# Altium schematic sheet USB3300 ULPI Board.SchDoc("USB3300 ULPI Board")
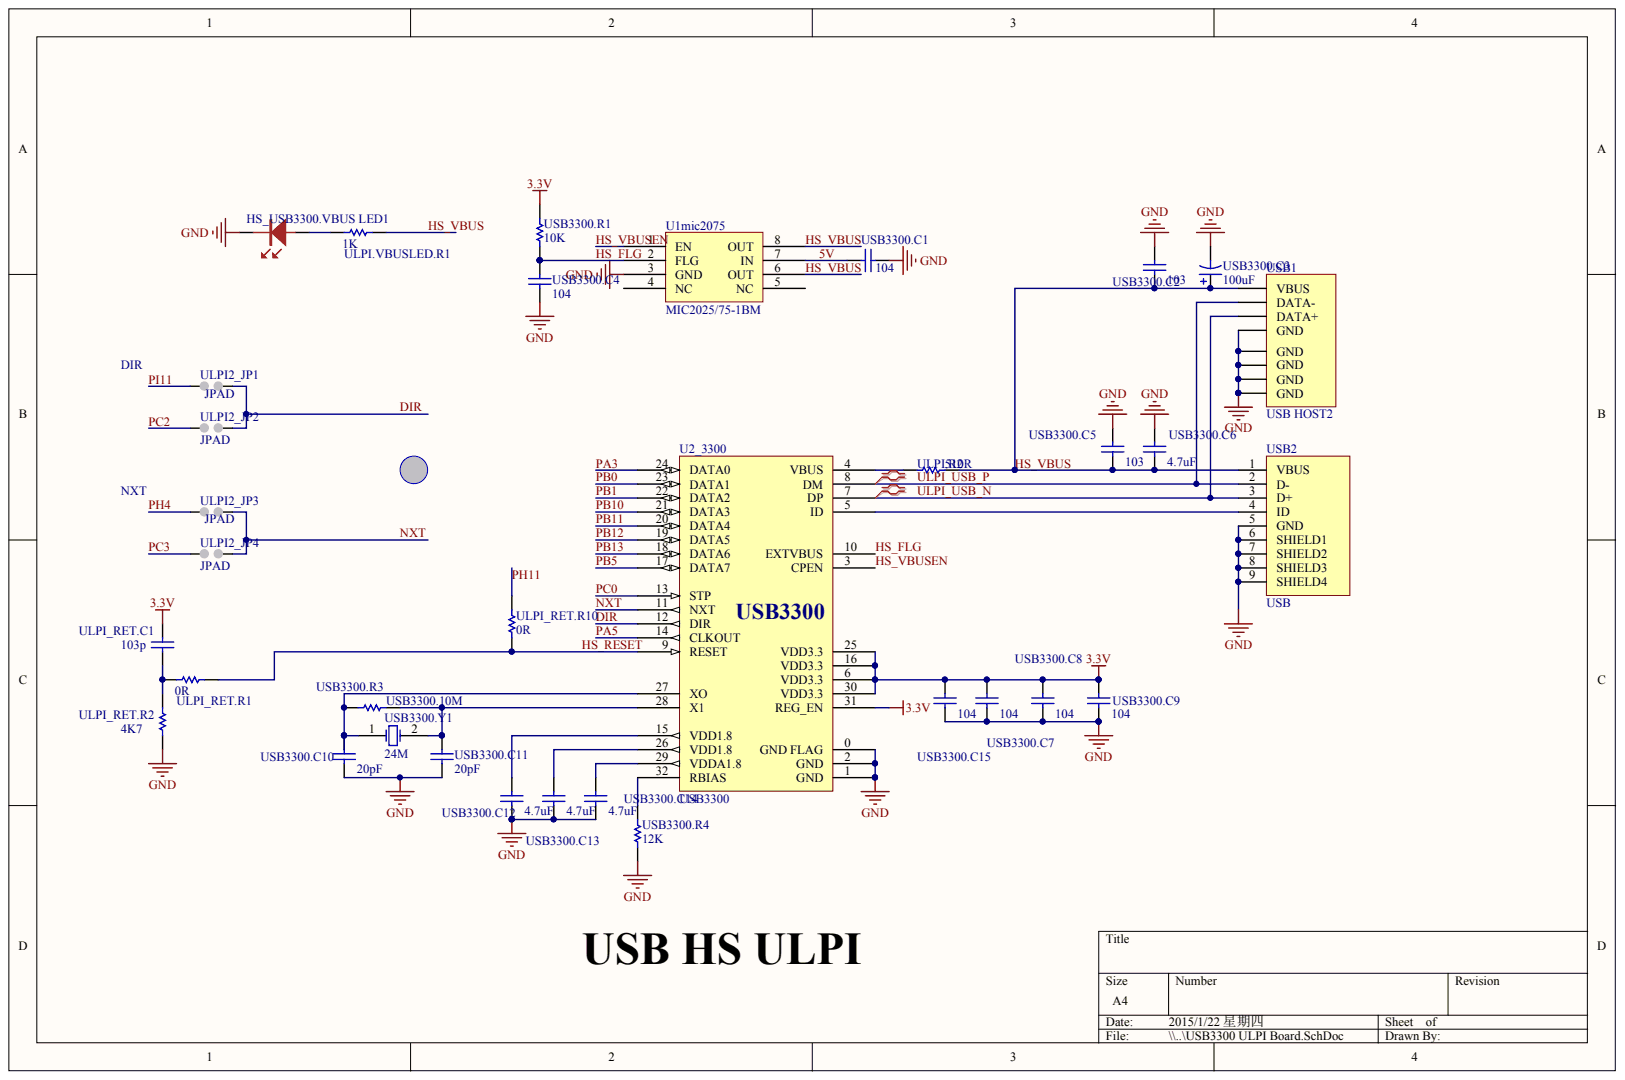
[diagram p0: USB3300 ULPI Board.SchDoc]

## Parts
HS_USB3300.VBUS_LED1  LED_0805  (value not drawn)
U1mic2075  MIC2025/75-1BM
U2_3300  USB3300
ULPI2_JP1  JPAD
ULPI2_JP2  JPAD
ULPI2_JP3  JPAD
ULPI2_JP4  JPAD
ULPI.R2  510R
ULPI.VBUSLED.R1  1K
ULPI_RET.C1  103p
ULPI_RET.R1  0R
ULPI_RET.R2  4K7
ULPI_RET.R10  0R
USB1  USB HOST2
USB2  USB
USB3300.C1  104
USB3300.C2  103
USB3300.C3  100uF
USB3300.C4  104
USB3300.C5  103
USB3300.C6  4.7uF
USB3300.C7  104
USB3300.C8  104
USB3300.C9  104
USB3300.C10  20pF
USB3300.C11  20pF
USB3300.C12  4.7uF
USB3300.C13  4.7uF
USB3300.C14  4.7uF
USB3300.C15  104
USB3300.R1  10K
USB3300.R3  USB3300.10M
USB3300.R4  12K
USB3300.Y1  24M

## Nets
3.3V: U2_3300.6(VDD3.3) U2_3300.16(VDD3.3) U2_3300.25(VDD3.3) U2_3300.30(VDD3.3) U2_3300.31(REG_EN) ULPI_RET.C1.2 USB3300.C7.1 USB3300.C8.1 USB3300.C9.1 USB3300.C15.1 USB3300.R1.1
GND: HS_USB3300.VBUS_LED1.2 U1mic2075.3(GND) U2_3300.0(GND_FLAG) U2_3300.1(GND) U2_3300.2(GND) ULPI_RET.R2.1 USB1.4(GND) USB1.5(GND) USB1.6(GND) USB1.7(GND) USB1.8(GND) USB2.5(GND) USB2.6(SHIELD1) USB2.7(SHIELD2) USB2.8(SHIELD3) USB2.9(SHIELD4) USB3300.C1.1 USB3300.C2.2 USB3300.C3.1 USB3300.C4.2 USB3300.C5.2 USB3300.C6.2 USB3300.C7.2 USB3300.C8.2 USB3300.C9.2 USB3300.C10.1 USB3300.C11.1 USB3300.C12.1 USB3300.C13.1 USB3300.C14.1 USB3300.C15.2 USB3300.R4.2
5V: U1mic2075.7(IN) USB3300.C1.2
DIR: U2_3300.12(DIR) ULPI2_JP1.1 ULPI2_JP2.1
HS_FLG: U1mic2075.2(FLG) U2_3300.10(EXTVBUS) USB3300.C4.1 USB3300.R1.2
HS_RESET: U2_3300.9(RESET) ULPI_RET.R1.1 ULPI_RET.R10.2
HS_VBUS: U1mic2075.6(OUT) U1mic2075.8(OUT) ULPI.R2.2 ULPI.VBUSLED.R1.1 USB1.1(VBUS) USB2.1(VBUS) USB3300.C2.1 USB3300.C3.2 USB3300.C5.1 USB3300.C6.1
HS_VBUSEN: U1mic2075.1(EN) U2_3300.3(CPEN)
NXT: U2_3300.11(NXT) ULPI2_JP3.1 ULPI2_JP4.1
PA3: U2_3300.24(DATA0)
PA5: U2_3300.14(CLKOUT)
PB0: U2_3300.23(DATA1)
PB1: U2_3300.22(DATA2)
PB5: U2_3300.17(DATA7)
PB10: U2_3300.21(DATA3)
PB11: U2_3300.20(DATA4)
PB12: U2_3300.19(DATA5)
PB13: U2_3300.18(DATA6)
PC0: U2_3300.13(STP)
PC2: ULPI2_JP2.2
PC3: ULPI2_JP4.2
PH4: ULPI2_JP3.2
PH11: ULPI_RET.R10.1
PI11: ULPI2_JP1.2
ULPI_USB_N: U2_3300.7(DP) USB1.3(DATA+) USB2.3(D+)
ULPI_USB_P: U2_3300.8(DM) USB1.2(DATA-) USB2.2(D-)
NetHS_USB3300.VBUS LED1_1: HS_USB3300.VBUS_LED1.1 ULPI.VBUSLED.R1.2
NetU2_3300_4: U2_3300.4(VBUS) ULPI.R2.1
NetU2_3300_5: U2_3300.5(ID) USB2.4(ID)
NetU2_3300_15: U2_3300.15(VDD1.8) USB3300.C12.2
NetU2_3300_26: U2_3300.26(VDD1.8) USB3300.C13.2
NetU2_3300_27: U2_3300.27(XO) USB3300.C10.2 USB3300.R3.1 USB3300.Y1.1
NetU2_3300_28: U2_3300.28(X1) USB3300.C11.2 USB3300.R3.2 USB3300.Y1.2
NetU2_3300_29: U2_3300.29(VDDA1.8) USB3300.C14.2
NetU2_3300_32: U2_3300.32(RBIAS) USB3300.R4.1
NetULPI_RET.C1_1: ULPI_RET.C1.1 ULPI_RET.R1.2 ULPI_RET.R2.2

Not connected: U1mic2075 4(NC) 5(NC)

## Library data of the parts
ULPI.R2 USB3300.R1 USB3300.R3 USB3300.R4 = (no symbol name): Value: 1K; Published: 8-Jun-2000; LatestRevisionDate: 17-Jul-2002; LatestRevisionNote: Re-released for DXP Platform.; PackageReference: AXIAL-0.3; Publisher: Altium Limited
ULPI_RET.R1 ULPI_RET.R10 = (no symbol name): Value: 4K7
USB3300.C1 USB3300.C2 USB3300.C4-USB3300.C15 = (no symbol name): Value: 100pF
HS_USB3300.VBUS_LED1 U1mic2075 U2_3300 ULPI2_JP1-ULPI2_JP4 ULPI.VBUSLED.R1 ULPI_RET.C1 ULPI_RET.R2 USB1 USB2 USB3300.C3 USB3300.Y1 = (no symbol name)
  ULPI.VBUSLED.R1: Value: 1K
  ULPI_RET.C1: Value: 103p
  ULPI_RET.R2: Value: 4K7
  USB3300.Y1: Published: 8-Jun-2000; LatestRevisionDate: 17-Jul-2002; LatestRevisionNote: Re-released for DXP Platform.; PackageReference: R38; Publisher: Altium Limited
